# Altium schematic sheet VBAT to 12V.SchDoc
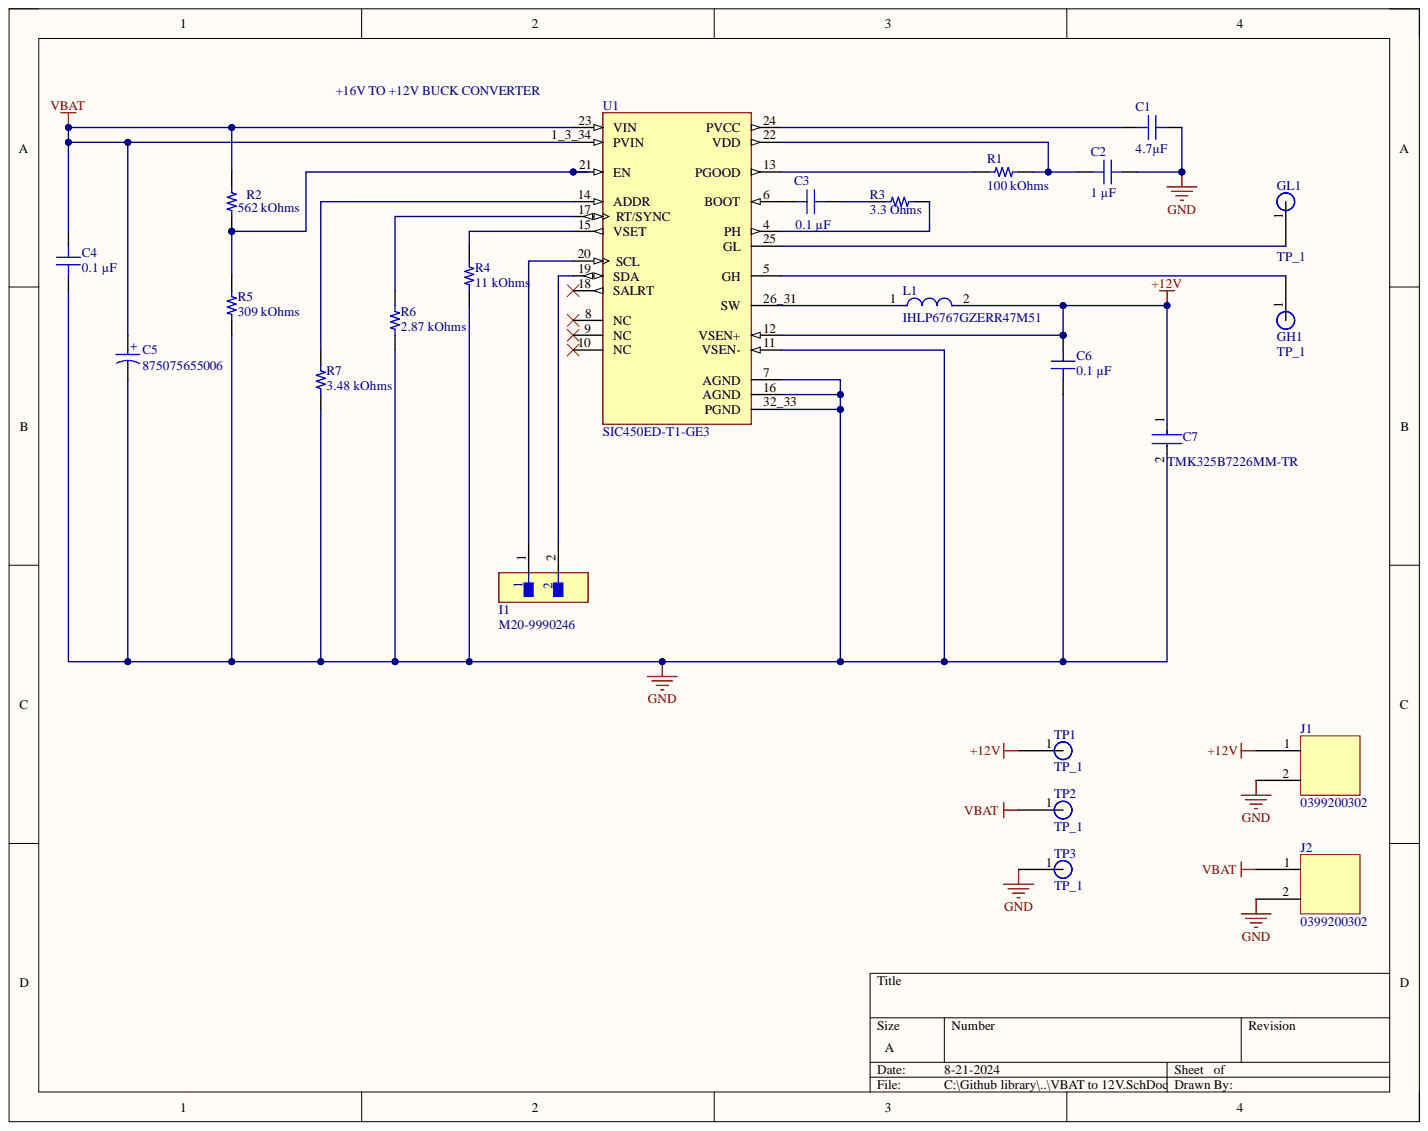
[diagram p0: VBAT to 12V.SchDoc]

## Parts
C1  4.7µF  [CAP 0603_1608]
C2  1 µF  [CAP 0603_1608]
C3  0.1 µF  [CAP 0402_1005]
C4  0.1 µF  [CAP 0402_1005]
C5  875075655006  [WCAP-PSLC_D8H11.7]
C6  0.1 µF  [CAP 0402_1005]
C7  TMK325B7226MM-TR  [FP-1210-L_3_2_0_3-W_2_5_0-MFG]
GH1  TP_1  [Test_point1]  (no BOM)
GL1  TP_1  [Test_point1]  (no BOM)
I1  M20-9990246  [FP-M20-9990246-MFG]
J1  0399200302  [0399200302]  (no BOM)
J2  0399200302  [0399200302]  (no BOM)
L1  IHLP6767GZERR47M51  [FP-IHLP-6767GZ-FP_3_825x1-MFG]
R1  100 kOhms  [RES 0402_1005]
R2  562 kOhms  [RES 0603_1608]
R3  3.3 Ohms  [RES 0402_1005]
R4  11 kOhms  [RES 0603_1608]
R5  309 kOhms  [RES 0603_1608]
R6  2.87 kOhms  [RES 0603_1608]
R7  3.48 kOhms  [RES 0603_1608]
TP1  TP_1  [Test_point1]  (no BOM)
TP2  TP_1  [Test_point1]  (no BOM)
TP3  TP_1  [Test_point1]  (no BOM)
U1  SIC450ED-T1-GE3  [VREG_SIC450ED-T1-GE3]

## Nets
+12V: C6.2 C7.1 J1.1 L1.2 TP1.1 U1.12(VSEN+)
GND: C1.1 C2.1 C4.1 C5.2 C6.1 C7.2 J1.2 J2.2 R4.1 R5.1 R6.1 R7.1 TP3.1 U1.7(AGND) U1.11(VSEN-) U1.16(AGND) U1.32_33(PGND)
VBAT: C4.2 C5.1 J2.1 R2.2 TP2.1 U1.1_3_34(PVIN) U1.23(VIN)
NetC1_2: C1.2 U1.24(PVCC)
NetC2_2: C2.2 R1.1 U1.22(VDD)
NetC3_1: C3.1 U1.6(BOOT)
NetC3_2: C3.2 R3.1
NetGH1_1: GH1.1 U1.5(GH)
NetGL1_1: GL1.1 U1.25(GL)
NetI1_1: I1.1 U1.20(SCL)
NetI1_2: I1.2 U1.19(SDA)
NetL1_1: L1.1 U1.26_31(SW)
NetR1_2: R1.2 U1.13(PGOOD)
NetR2_1: R2.1 R5.2 U1.21(EN)
NetR3_2: R3.2 U1.4(PH)
NetR4_2: R4.2 U1.15(VSET)
NetR6_2: R6.2 U1.17(RT/SYNC)
NetR7_2: R7.2 U1.14(ADDR)

Not connected: U1 8(NC) 9(NC) 10(NC) 18(SALRT)

## Library data of the parts
C1 = 06036D475KAT4A: CAP CER 4.7UF 6.3V X5R 0603; manufacturer: AVX Corporation; Database Table Name: Capacitors - Ceramic - 0603; Tolerance: ±10%; Applications: General Purpose; Capacitance: 4.7µF; Component Kind: Standard; componentlink1description: Datasheet; componentlink1url: http://datasheets.avx.com/cx5r.pdf; componentlink2description: DigiKey Link; componentlink2url: http://digikey.com/product-detail/en/avx-corporation/06036D475KAT4A/478-10813-1-ND/7536337; Device Package: 0603 (1608 Metric); lastupdated: 2020-09-02 1:03:34 AM; Library Name: Celestial Altium Library - altium_library (2).DbLib; Lifecycle Status: Active; Minimum Order: 1; Mounting Type: Surface Mount, MLCC; Operating Temperature: -55°C ~ 85°C; Package / Case: 0603 (1608 Metric); packaging: TapeAndReel; Part Number: 06036D475KAT4A; Part Status: Active; partid: 2929; price: 0.02; series: -; Size / Dimension: 0.063" L x 0.032" W (1.60mm x 0.81mm); Supplier 1: DigiKey; Supplier Part Number 1: 478-10813-1-ND; Temperature Coefficient: X5R; Thickness (Max): 0.037" (0.94mm); Value: 4.7µF; Voltage - Rated: 6.3V
C2 = C0603X105M9RACAUTO: CAP CER 1UF 6.3V X7R 0603; manufacturer: KEMET; Database Table Name: Capacitors - Ceramic - 0603; Tolerance: ±20%; Applications: Automotive, Boardflex Sensitive; Capacitance: 1 µF; Component Kind: Standard; componentlink1description: Datasheet; componentlink1url: https://api.kemet.com/component-edge/download/datasheet/C0603X105M9RACAUTO.pdf; componentlink2description: DigiKey Link; componentlink2url: https://www.digikey.com/en/products/detail/kemet/C0603X105M9RACAUTO/8635848; Device Package: 0603 (1608 Metric); Features: Soft Termination; lastupdated: 2024-01-28 8:09:58 PM; Library Name: Celestial Altium Library - altium_library (2).DbLib; Lifecycle Status: Active; Minimum Order: 1; Mounting Type: Surface Mount, MLCC; Operating Temperature: -55°C ~ 125°C; Package / Case: 0603 (1608 Metric); packaging: TapeAndReel; Part Number: C0603X105M9RACAUTO; Part Status: Active; partid: 2401; price: 0.06; Ratings: AEC-Q200; series: SMD Auto X7R Flex; Size / Dimension: 0.063" L x 0.031" W (1.60mm x 0.80mm); Supplier 1: DigiKey; Supplier Part Number 1: 399-17721-1-ND; Temperature Coefficient: X7R; Thickness (Max): 0.037" (0.95mm); Value: 1 µF; Voltage - Rated: 6.3V
C3 = CL05B104KP5VPNC: CAP CER 100NF 10V X7R 0402; manufacturer: Samsung Electro-Mechanics; Database Table Name: Capacitors - Ceramic - 0402; Tolerance: ±10%; Applications: Automotive; Capacitance: 0.1 µF; Component Kind: Standard; componentlink1description: Datasheet; componentlink1url: https://mm.digikey.com/Volume0/opasdata/d220001/medias/docus/5458/CL05B104KP5VPNC_Spec.pdf; componentlink2description: DigiKey Link; componentlink2url: https://www.digikey.com/en/products/detail/samsung-electro-mechanics/CL05B104KP5VPNC/20498482; Device Package: 0402 (1005 Metric); lastupdated: 2024-01-28 8:02:59 PM; Library Name: Celestial Altium Library - altium_library (2).DbLib; Lifecycle Status: Active; Minimum Order: 1; Mounting Type: Surface Mount, MLCC; Operating Temperature: -55°C ~ 125°C; Package / Case: 0402 (1005 Metric); packaging: TapeAndReel; Part Number: CL05B104KP5VPNC; partid: 56690; price: 0.01; Ratings: AEC-Q200; series: CL; Size / Dimension: 0.039" L x 0.020" W (1.00mm x 0.50mm); Supplier 1: DigiKey; Supplier Part Number 1: 1276-CL05B104KP5VPNCCT-ND; Temperature Coefficient: X7R; Thickness (Max): 0.022" (0.55mm); Value: 0.1 µF; Voltage - Rated: 10V
C4 C6 = GCM155R71E104KE02D: CAP CER 0.1UF 25V X7R 0402; manufacturer: Murata Electronics; Database Table Name: Capacitors - Ceramic - 0402; Tolerance: ±10%; Applications: Automotive; Capacitance: 0.1 µF; Component Kind: Standard; componentlink1description: Datasheet; componentlink1url: https://search.murata.co.jp/Ceramy/image/img/A01X/G101/ENG/GCM155R71E104KE02-01.pdf; componentlink2description: DigiKey Link; componentlink2url: https://www.digikey.com/en/products/detail/murata-electronics/GCM155R71E104KE02D/7363175; Device Package: 0402 (1005 Metric); Library Name: Celestial Altium Library - altium_library (2).DbLib; Lifecycle Status: Active; Minimum Order: 1; Mounting Type: Surface Mount, MLCC; Operating Temperature: -55°C ~ 125°C; Package / Case: 0402 (1005 Metric); packaging: TapeAndReel; Part Number: GCM155R71E104KE02D; Part Status: Active; partid: 2051; price: 0.01; Ratings: AEC-Q200; series: GCM; Size / Dimension: 0.039" L x 0.020" W (1.00mm x 0.50mm); Supplier 1: DigiKey; Supplier Part Number 1: 490-16431-1-ND; Temperature Coefficient: X7R; Thickness (Max): 0.022" (0.55mm); Value: 0.1 µF; Voltage - Rated: 25V
  C4: lastupdated: 2024-02-09 8:04:37 PM
  C6: lastupdated: 2024-01-28 8:04:19 PM
C5 = CMP-03913-90235614-1: 875075655006 Cap, 150Uf, 35V, Alu Elec Smd, Polymer Rohs Compliant: Yes; Capacitance: 150µF; ESR (Equivalent Series Resistance): 25mΩ; Leakage Current: 840µA; Ripple Current: 3.8A; Component Kind: Standard; Diameter: 8mm; Length: 11.7mm; Library Name: Altium Content Vault; Max Operating Temperature: 105°C; Min Operating Temperature: -55°C; NexarPartId: 90235614; RoHS: Compliant; Voltage Rating: 35V; Width: 8.3mm
C7 = CMP-14477-000122-1: CAP CER 22UF 25V X7R 1210; Tolerance: 20%; Capacitance: 22uF; Case Code (Imperial): 1210; Case Code (Metric): 3225; Case/Package: 1210; Component Kind: Standard; Construction: Flat; Depth: 2.5mm; Dielectric: X7R; Halogen Free: Halogen Free; Height: 2.5mm; Lead Free: Lead Free; Length: 3.2mm; Library Name: Altium Content Vault; Max Operating Temperature: 125°C; Min Operating Temperature: -55°C; Mount: Surface Mount; Number of Pins: 2; Package Quantity: 500; Packaging: Tape and Reel; Radiation Hardening: No; REACH SVHC: No SVHC; Resistor Type: Ceramic; RoHS Compliant: Yes; Series: M; Termination: SMD/SMT; Thickness: 0.106inch; Voltage: 25V; Voltage Rating: 25V; Voltage Rating (DC): 25V; Width: 0.098inch
GH1 GL1 TP1-TP3 = Test_point1: Library Name: Seaglider_Power_board_Schematic_Library.SchLib
I1 = CMP-15831-000009-1: CONN HEADER VERT 2POS 2.54MM; Manufacturer: Harwin; Conn Gender: Male; Conn Orientation: Vertical; Current Rating: 3A; Number Of Contacts: 2; Automotive: No; Category: Conn; Component Kind: Standard; ComponentLink2Description: Datasheet; ComponentLink2URL: https://cdn.harwin.com/pdfs/M20-999.pdf; Contact Material: Tin,Nickel; Device Class L1: Connectors; Device Class L2: Headers and Wire Housings; Device Class L3: unset; Digikey Description: CONN HEADER VERT 2POS 2.54MM; Height: 8.99mm; Lead Free: Yes; Library Name: Altium Content Vault; Manufacturer Part Number: M20-9990246; Mouser Description: 2.54mm (0.1") Pitch SIL Vertical PC Tail Pin Header, 6.1mm mating pin height, tin, 2 contacts; Number Of Rows: 1; Octopart: https://octopart.com/m20-9990246-harwin-2590635; Package: M20-9990246; Pitch: 2.54mm; RoHS: TRUE; Temperature: -40°C to +105°C; Temperature Range High: +105°C; Temperature Range Low: -40°C
L1 = CMP-02424-000445-1: Commercial Inductors, High Temperature (155 °C) Series 0.47uH 65A 0.95mΩ 20%; DC Resistance (DCR): 0.95mR; Inductance: 470nH; Max DC Current: 63A; Resistance: 950R; Saturation Current: 76A; Self Resonant Frequency: 52.3MHz; Shielding: Shielded; Test Frequency: 100kHz; Case/Package: 6767; Component Kind: Standard; Current Rating: 65A; Depth: 17.5mm; Height: 7mm; Height - Seated (Max): 0.276inch; Inductance Tolerance: 20%; Lead Free: Lead Free; Length: 0.675inch; Library Name: Altium Content Vault; Max Operating Temperature: 155°C; Min Operating Temperature: -55°C; Mount: Surface Mount; Package Quantity: 200; Packaging: Tape and Reel; Radiation Hardening: No; RMS Current (Irms): 63A; Termination: SMD/SMT; Tolerance: 20%; Weight: 0.417996oz; Width: 17.15mm
R1 = RT0402FRE07100KL: RES SMD 100K OHM 1% 1/16W 0402; Manufacturer: Yageo; Database Table Name: Resistors - Surface Mount - 0402; Power (Watts): 0.063W, 1/16W; Temperature Coefficient: ±50ppm/°C; Component Kind: Standard; ComponentLink1Description: Datasheet; ComponentLink1URL: https://www.yageo.com/upload/media/product/productsearch/datasheet/rchip/PYu-RT_1-to-0.01_RoHS_L_11.pdf; ComponentLink2Description: DigiKey Link; ComponentLink2URL: https://www.digikey.com/en/products/detail/yageo/RT0402FRE07100KL/1071698; Composition: Thin Film; Device Package: 0402 (1005 Metric); Height - Seated (Max): 0.014" (0.35mm); LastUpdated: 2024-01-28 8:28:38 PM; Library Name: Celestial Altium Library - altium_library (2).DbLib; Lifecycle Status: Active; Minimum Order: 1; Number of Terminations: 2; Operating Temperature: -55°C ~ 155°C; Package / Case: 0402 (1005 Metric); Packaging: TapeAndReel; Part Number: RT0402FRE07100KL; Part Status: Active; PartId: 3190; Price: 0.01; Resistance: 100 kOhms; Series: RT; Size / Dimension: 0.039" L x 0.020" W (1.00mm x 0.50mm); Supplier 1: DigiKey; Supplier Device Package: 0402; Supplier Part Number 1: YAG2307CT-ND; Tolerance: ±1%; Value: 100 kOhms
R2 = RK73H1JTTD5623F: RES 562K OHM 1% 1/10W 0603; Manufacturer: KOA Speer Electronics, Inc.; Database Table Name: Resistors - Surface Mount - 0603; Power (Watts): 0.1W, 1/10W; Temperature Coefficient: ±100ppm/°C; Component Kind: Standard; ComponentLink1Description: Datasheet; ComponentLink1URL: http://www.koaspeer.com/catimages/Products/RK73H/RK73H.pdf; ComponentLink2Description: DigiKey Link; ComponentLink2URL: https://www.digikey.com/en/products/detail/koa-speer-electronics-inc/RK73H1JTTD5623F/9845175; Composition: Thick Film; Device Package: 0603 (1608 Metric); Features: Automotive AEC-Q200, Moisture Resistant; Height - Seated (Max): 0.022" (0.55mm); LastUpdated: 2024-01-28 8:39:45 PM; Library Name: Celestial Altium Library - altium_library (2).DbLib; Lifecycle Status: Active; Minimum Order: 1; Number of Terminations: 2; Operating Temperature: -55°C ~ 155°C; Package / Case: 0603 (1608 Metric); Packaging: CutTape; Part Number: RK73H1JTTD5623F; Part Status: Active; PartId: 8595; Price: 0.01; Ratings: AEC-Q200; Resistance: 562 kOhms; Series: RK73H; Size / Dimension: 0.063" L x 0.031" W (1.60mm x 0.80mm); Supplier 1: DigiKey; Supplier Device Package: 0603; Supplier Part Number 1: 2019-RK73H1JTTD5623FCT-ND; Tolerance: ±1%; Value: 562 kOhms
R3 = ERJ-2GEJ3R3X: RES SMD 3.3 OHM 5% 1/10W 0402; Manufacturer: Panasonic Electronic Components; Database Table Name: Resistors - Surface Mount - 0402; Power (Watts): 0.1W, 1/10W; Temperature Coefficient: -100/ +600ppm/°C; Component Kind: Standard; ComponentLink1Description: Datasheet; ComponentLink1URL: https://b2b-api.panasonic.eu/file_stream/pids/fileversion/1242; ComponentLink2Description: DigiKey Link; ComponentLink2URL: https://www.digikey.com/en/products/detail/panasonic-electronic-components/ERJ-2GEJ3R3X/282082; Composition: Thick Film; Device Package: 0402 (1005 Metric); Features: Automotive AEC-Q200; Height - Seated (Max): 0.016" (0.40mm); LastUpdated: 2024-01-28 8:28:37 PM; Library Name: Celestial Altium Library - altium_library (2).DbLib; Lifecycle Status: Active; Minimum Order: 1; Number of Terminations: 2; Operating Temperature: -55°C ~ 155°C; Package / Case: 0402 (1005 Metric); Packaging: CutTape; Part Number: ERJ-2GEJ3R3X; Part Status: Active; PartId: 3568; Price: 0.01; Ratings: AEC-Q200; Resistance: 3.3 Ohms; Series: ERJ-2G; Size / Dimension: 0.039" L x 0.020" W (1.00mm x 0.50mm); Supplier 1: DigiKey; Supplier Device Package: 0402; Supplier Part Number 1: P3.3JCT-ND; Tolerance: ±5%; Value: 3.3 Ohms
R4 = TNPW060311K0BYEN: RES 11K OHM 0.1% 1/10W 0603; Manufacturer: Vishay Dale; Database Table Name: Resistors - Surface Mount - 0603; Power (Watts): 0.1W, 1/10W; Temperature Coefficient: ±10ppm/°C; Component Kind: Standard; ComponentLink1Description: Datasheet; ComponentLink1URL: http://www.vishay.com/docs/28758/tnpw_e3.pdf; ComponentLink2Description: DigiKey Link; ComponentLink2URL: https://www.digikey.com/en/products/detail/vishay-dale/TNPW060311K0BYEN/8636632; Composition: Thin Film; Device Package: 0603 (1608 Metric); Features: Anti-Sulfur, Automotive AEC-Q200, Moisture Resistant; Height - Seated (Max): 0.022" (0.55mm); LastUpdated: 2024-01-28 8:39:55 PM; Library Name: Celestial Altium Library - altium_library (2).DbLib; Lifecycle Status: Active; Minimum Order: 1; Number of Terminations: 2; Operating Temperature: -55°C ~ 155°C; Package / Case: 0603 (1608 Metric); Packaging: TapeAndReel; Part Number: TNPW060311K0BYEN; Part Status: Active; PartId: 6393; Price: 0.25; Ratings: AEC-Q200; Resistance: 11 kOhms; Series: TNPW e3; Size / Dimension: 0.061" L x 0.033" W (1.55mm x 0.85mm); Supplier 1: DigiKey; Supplier Device Package: 0603; Supplier Part Number 1: 541-9816-1-ND; Tolerance: ±0.1%; Value: 11 kOhms
R5 = RG1608P-3093-B-T5: RES SMD 309K OHM 0.1% 1/10W 0603; Manufacturer: Susumu; Database Table Name: Resistors - Surface Mount - 0603; Power (Watts): 0.1W, 1/10W; Temperature Coefficient: ±25ppm/°C; Component Kind: Standard; ComponentLink1Description: Datasheet; ComponentLink1URL: //media.digikey.com/pdf/Data%20Sheets/Susumu%20PDFs/RG_RM_RGH_Oct2012.pdf; ComponentLink2Description: DigiKey Link; ComponentLink2URL: https://www.digikey.com/en/products/detail/susumu/RG1608P-3093-B-T5/1240553; Composition: Thin Film; Device Package: 0603 (1608 Metric); Features: Anti-Sulfur, Automotive AEC-Q200; Height - Seated (Max): 0.020" (0.50mm); LastUpdated: 2024-01-28 8:39:51 PM; Library Name: Celestial Altium Library - altium_library (2).DbLib; Lifecycle Status: Active; Minimum Order: 1; Number of Terminations: 2; Operating Temperature: -55°C ~ 155°C; Package / Case: 0603 (1608 Metric); Packaging: TapeAndReel; Part Number: RG1608P-3093-B-T5; Part Status: Active; PartId: 7313; Price: 0.05; Ratings: AEC-Q200; Resistance: 309 kOhms; Series: RG; Size / Dimension: 0.063" L x 0.031" W (1.60mm x 0.80mm); Supplier 1: DigiKey; Supplier Device Package: 0603; Supplier Part Number 1: RG16P309KBCT-ND; Tolerance: ±0.1%; Value: 309 kOhms
R6 = RC0603FR-072K87L: RES 2.87K OHM 1% 1/10W 0603; Manufacturer: Yageo; Database Table Name: Resistors - Surface Mount - 0603; Power (Watts): 0.1W, 1/10W; Temperature Coefficient: ±100ppm/°C; Component Kind: Standard; ComponentLink1Description: Datasheet; ComponentLink1URL: https://www.yageo.com/upload/media/product/productsearch/datasheet/rchip/PYu-RC_Group_51_RoHS_L_10.pdf; ComponentLink2Description: DigiKey Link; ComponentLink2URL: https://www.digikey.com/en/products/detail/yageo/RC0603FR-072K87L/727036; Composition: Thick Film; Device Package: 0603 (1608 Metric); Features: Moisture Resistant; Height - Seated (Max): 0.022" (0.55mm); LastUpdated: 2024-01-28 8:39:50 PM; Library Name: Celestial Altium Library - altium_library (2).DbLib; Lifecycle Status: Active; Minimum Order: 1; Number of Terminations: 2; Operating Temperature: -55°C ~ 155°C; Package / Case: 0603 (1608 Metric); Packaging: CutTape; Part Number: RC0603FR-072K87L; Part Status: Active; PartId: 7498; Price: 0.01; Resistance: 2.87 kOhms; Series: RC_L; Size / Dimension: 0.063" L x 0.031" W (1.60mm x 0.80mm); Supplier 1: DigiKey; Supplier Device Package: 0603; Supplier Part Number 1: 311-2.87KHRCT-ND; Tolerance: ±1%; Value: 2.87 kOhms
R7 = RNCP0603FTD3K48: RES 3.48K OHM 1% 1/8W 0603; Manufacturer: Stackpole Electronics Inc; Database Table Name: Resistors - Surface Mount - 0603; Power (Watts): 0.125W, 1/8W; Temperature Coefficient: ±100ppm/°C; Component Kind: Standard; ComponentLink1Description: Datasheet; ComponentLink1URL: https://www.seielect.com/catalog/sei-rncp.pdf; ComponentLink2Description: DigiKey Link; ComponentLink2URL: https://www.digikey.com/en/products/detail/stackpole-electronics-inc/RNCP0603FTD3K48/2240122; Composition: Thin Film; Device Package: 0603 (1608 Metric); Features: Anti-Sulfur; Height - Seated (Max): 0.020" (0.50mm); LastUpdated: 2024-01-28 8:39:52 PM; Library Name: Celestial Altium Library - altium_library (2).DbLib; Lifecycle Status: Active; Minimum Order: 1; Number of Terminations: 2; Operating Temperature: -55°C ~ 155°C; Package / Case: 0603 (1608 Metric); Packaging: TapeAndReel; Part Number: RNCP0603FTD3K48; Part Status: Active; PartId: 6983; Price: 0.01; Resistance: 3.48 kOhms; Series: RNCP; Size / Dimension: 0.059" L x 0.031" W (1.50mm x 0.80mm); Supplier 1: DigiKey; Supplier Device Package: 0603; Supplier Part Number 1: RNCP0603FTD3K48CT-ND; Tolerance: ±1%; Value: 3.48 kOhms
U1 = CMP-351-000000-2: Buck Switching Regulator IC Positive Adjustable 0.3V 1 Output 40A 34-PowerWFQFN; MF: Vishay Siliconix; Availability: In Stock; Check_prices: https://www.snapeda.com/parts/SIC450ED-T1-GE3/Vishay+Siliconix/view-part/?ref=eda; Component Kind: Standard; Component Type: Standard; Design Item ID: SIC450ED-T1-GE3; MP: SIC450ED-T1-GE3; Package: PowerWFQFN-34 Vishay Siliconix; Pin Count: 25; Price: None; Purchase-URL: https://pricing.snapeda.com/search/part/SIC450ED-T1-GE3/?ref=eda; SnapEDA_Link: https://www.snapeda.com/parts/SIC450ED-T1-GE3/Vishay+Siliconix/view-part/?ref=snap; Source: SIC450ED-T1-GE3.SchLib; Library Name: Americas- Environmental Engineering Club- University of Victoria
J1 J2 = 0399200302: Library Name: Seaglider_Power_board_Schematic_Library.SchLib
